# Altium schematic sheet Pulse.SchDoc(Pulse)
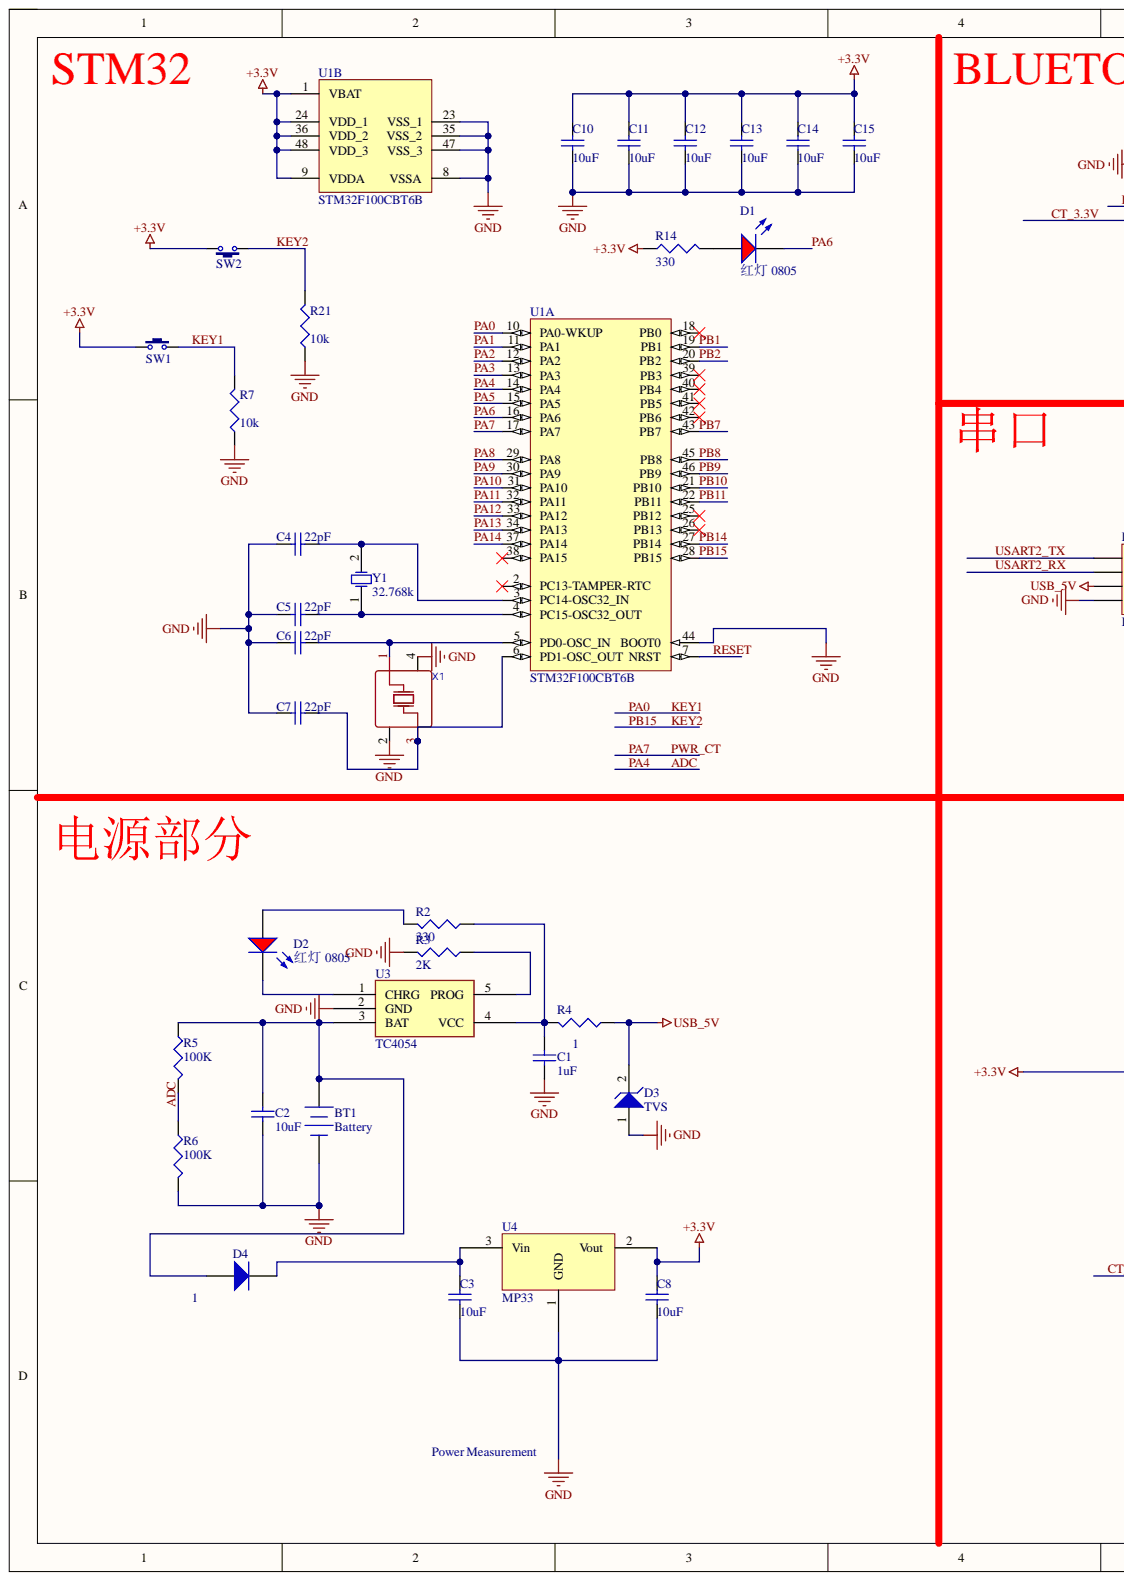
[diagram p0: Pulse.SchDoc, left]
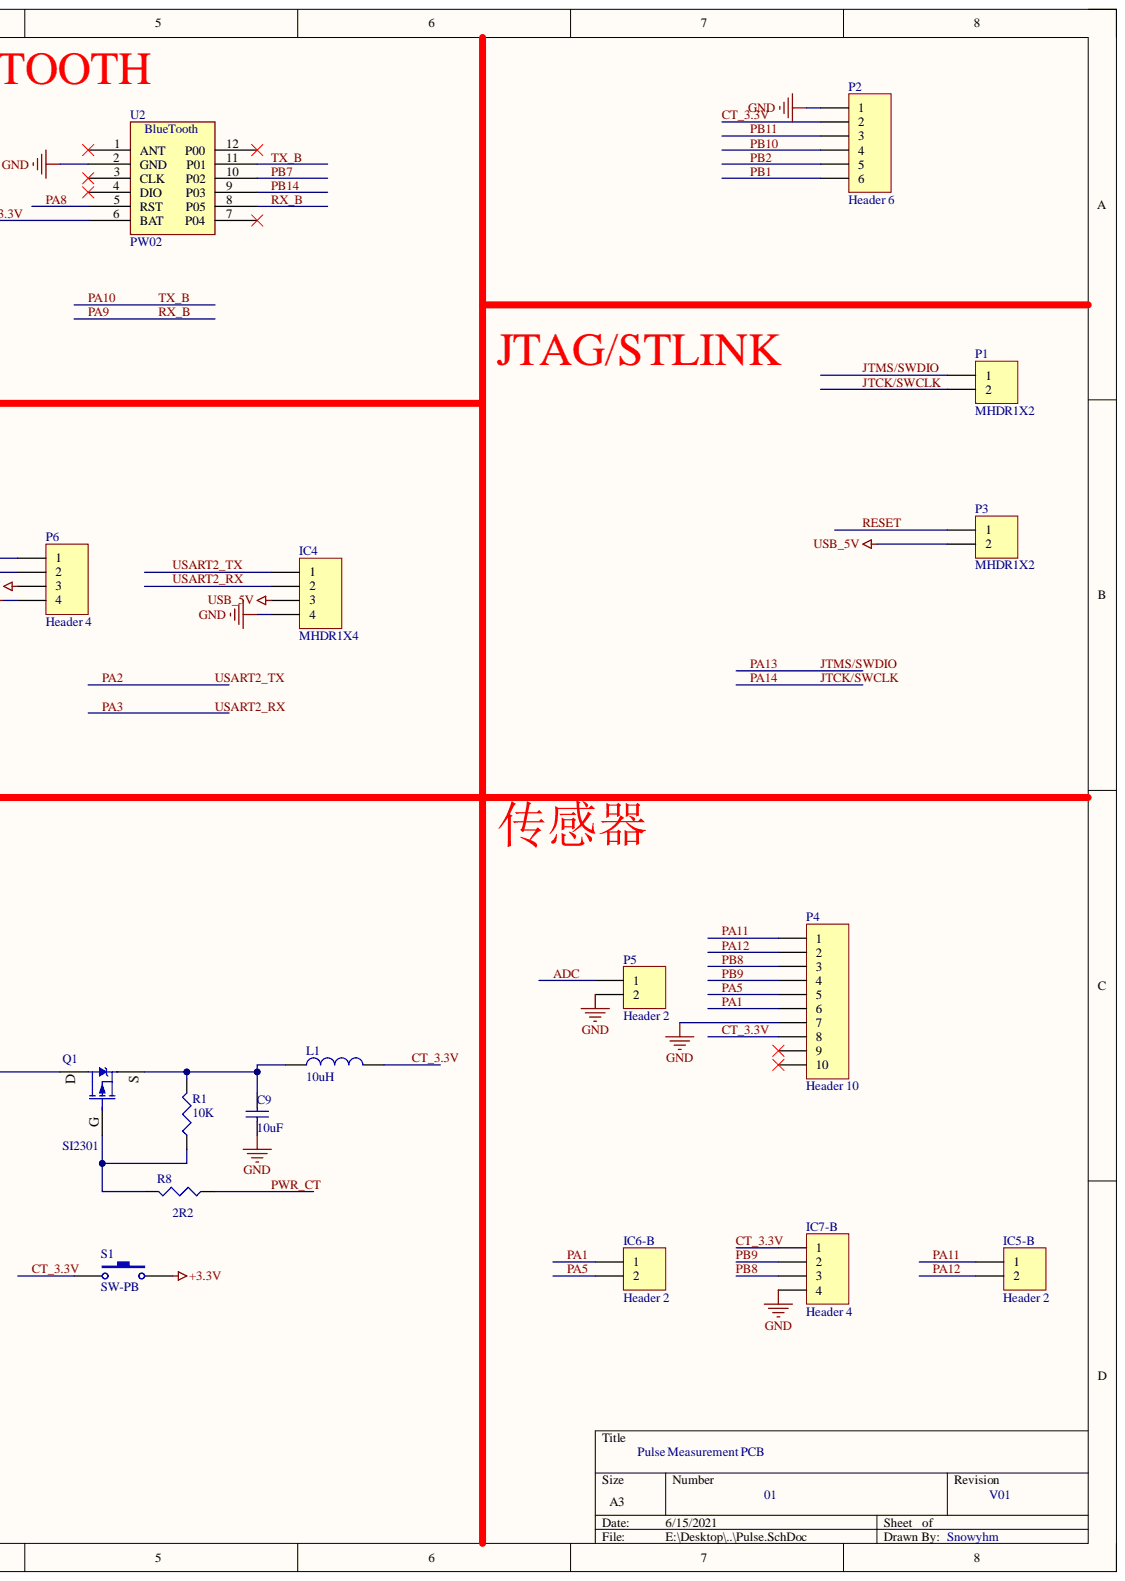
[diagram p1: Pulse.SchDoc, right]

## Parts
BT1  Battery  [BAT-2]
C1  value 1uF  [LC-0603_C]  (hidden comment: Cap Semi)
C2  value 10uF  [LC-0603_C]  (hidden comment: Cap Semi)
C3  value 10uF  [LC-0603_C]  (hidden comment: Cap Semi)
C4  value 22pF  [LC-0603_C]  (hidden comment: Cap Semi)
C5  value 22pF  [LC-0603_C]  (hidden comment: Cap Semi)
C6  value 22pF  [LC-0603_C]  (hidden comment: Cap Semi)
C7  value 22pF  [LC-0603_C]  (hidden comment: Cap Semi)
C8  value 10uF  [LC-0603_C]  (hidden comment: Cap Semi)
C9  value 10uF  [LC-0603_C]  (hidden comment: Cap Semi)
C10  value 10uF  [LC-0603_C]  (hidden comment: Cap Semi)
C11  value 10uF  [LC-0603_C]  (hidden comment: Cap Semi)
C12  value 10uF  [LC-0603_C]  (hidden comment: Cap Semi)
C13  value 10uF  [LC-0603_C]  (hidden comment: Cap Semi)
C14  value 10uF  [LC-0603_C]  (hidden comment: Cap Semi)
C15  value 10uF  [LC-0603_C]  (hidden comment: Cap Semi)
D1  红灯 0805  Package: 0805  [LC-0805_LED_S1]
D2  红灯 0805  [LC-0805_LED_S1]
D3  TVS  [LC-SOD-123]
D4  1  [LC-SOD-123]
IC4  MHDR1X4  [MHDR1X4]
IC5-B  Header 2  [HDR1X2]
IC6-B  Header 2  [HDR1X2]
IC7-B  Header 4  [HDR1X4]
L1  10uH  [L 0805]
P1  MHDR1X2  [MHDR1X2]
P2  Header 6  [HDR1X6]
P3  MHDR1X2  [MHDR1X2]
P4  Header 10  [8P]
P5  Header 2  [HDR1X2]
P6  Header 4  [fpc_4p]
Q1  SI2301  [SOT23-3N]
R1  value 10K  [LC-0603_R]  (hidden comment: Res3)
R2  value 330  [LC-0603_R]  (hidden comment: Res3)
R3  value 2K  [LC-0603_R]  (hidden comment: Res3)
R4  value 1  [LC-0603_R]  (hidden comment: Res3)
R5  value 100K  [LC-0603_R]  (hidden comment: Res3)
R6  value 100K  [LC-0603_R]  (hidden comment: Res3)
R7  value 10k  [LC-0603_R]  (hidden comment: Res3)
R8  value 2R2  [LC-0603_R]  (hidden comment: Res3)
R14  value 330  [LC-0603_R]  (hidden comment: Res3)
R21  value 10k  [LC-0603_R]  (hidden comment: Res3)
S1  SW-PB  [SW-SMD_DSHP01TSGET]
SW1  3x6x5  [button]  (value not drawn)
SW2  3x6x5  [button]  (value not drawn)
U1  STM32F100CBT6B  [STM-LQFP48_L]  (parts A,B)
U2  PW02  [bluetooth]
U3  TC4054  [SOT23-5]
U4  MP33  [LC-SOT-23(SOT-23-3)]
X1  (no value)  [OSC 3225-4P]
Y1  32.768k  [OSC 3215-2P]

## Nets
+3.3V: C8.1 C10.1 C11.1 C12.1 C13.1 C14.1 C15.1 Q1.3 R14.1 S1.2 SW1.1 SW2.2 U1.1(VBAT) U1.9(VDDA) U1.24(VDD_1) U1.36(VDD_2) U1.48(VDD_3) U4.2
GND: BT1.2 C1.1 C2.1 C3.2 C4.1 C5.1 C6.1 C7.1 C8.2 C9.2 C10.2 C11.2 C12.2 C13.2 C14.2 C15.2 D3.1 IC4.4 IC7-B.4 P2.1 P4.7 P5.2 P6.4 R3.2 R6.2 R7.1 R21.1 U1.8(VSSA) U1.23(VSS_1) U1.35(VSS_2) U1.44(BOOT0) U1.47(VSS_3) U2.2(GND) U3.2(GND) U4.1 X1.2 X1.4
USB_5V: D3.2 IC4.3 P3.2 P6.3 R4.1
ADC: P5.1 R5.2 R6.1 U1.14(PA4)
CT_3.3V: IC7-B.1 L1.2 P2.2 P4.8 S1.1 U2.6(BAT)
JTCK/SWCLK: P1.2 U1.37(PA14)
JTMS/SWDIO: P1.1 U1.34(PA13)
KEY1: R7.2 SW1.2 U1.10(PA0-WKUP)
KEY2: R21.2 SW2.1 U1.28(PB15)
PA1: IC6-B.1 P4.6 U1.11(PA1)
PA2: IC4.1 P6.1 U1.12(PA2)
PA3: IC4.2 P6.2 U1.13(PA3)
PA5: IC6-B.2 P4.5 U1.15(PA5)
PA6: D1.K U1.16(PA6)
PA7: R8.1 U1.17(PA7)
PA8: U1.29(PA8) U2.5(RST)
PA9: U1.30(PA9) U2.8(P05)
PA10: U1.31(PA10) U2.11(P01)
PA11: IC5-B.1 P4.1 U1.32(PA11)
PA12: IC5-B.2 P4.2 U1.33(PA12)
PB1: P2.6 U1.19(PB1)
PB2: P2.5 U1.20(PB2)
PB7: U1.43(PB7) U2.10(P02)
PB8: IC7-B.3 P4.3 U1.45(PB8)
PB9: IC7-B.2 P4.4 U1.46(PB9)
PB10: P2.4 U1.21(PB10)
PB11: P2.3 U1.22(PB11)
PB14: U1.27(PB14) U2.9(P03)
RESET: P3.1 U1.7(NRST)
NetBT1_1: BT1.1 C2.2 D4.1 R5.1 U3.3(BAT)
NetC1_2: C1.2 R2.1 R4.2 U3.4(VCC)
NetC3_1: C3.1 D4.2 U4.3
NetC4_2: C4.2 U1.3(PC14-OSC32_IN) Y1.2
NetC5_2: C5.2 U1.4(PC15-OSC32_OUT) Y1.1
NetC6_2: C6.2 U1.5(PD0-OSC_IN) X1.1
NetC7_2: C7.2 U1.6(PD1-OSC_OUT) X1.3
NetC9_1: C9.1 L1.1 Q1.2 R1.2
NetD1_A: D1.A R14.2
NetD2_A: D2.A R2.2
NetD2_K: D2.K U3.1(CHRG)
NetQ1_1: Q1.1 R1.1 R8.2
NetR3_1: R3.1 U3.5(PROG)

Not connected: P4 9 10; U1 2(PC13-TAMPER-RTC) 18(PB0) 25(PB12) 26(PB13) 38(PA15) 39(PB3) 40(PB4) 41(PB5) 42(PB6); U2 1(ANT) 3(CLK) 4(DIO) 7(P04) 12(P00)

## Library data of the parts
BT1 = Battery: Multicell Battery; Component Kind: Standard; LatestRevisionDate: 17-Jul-2002; LatestRevisionNote: Re-released for DXP Platform.; Library Name: Miscellaneous Devices.IntLib; PackageDescription: Battery; 2 Leads; PackageReference: BAT-2; Published: 24-Mar-1999; Publisher: Altium Limited
C1-C15 = Cap Semi: Capacitor (Semiconductor SIM Model); Component Kind: Standard; LatestRevisionDate: 29-May-2009; LatestRevisionNote: IPC-7351 Footprint Added.; Library Name: Miscellaneous Devices.IntLib; PackageDescription: Chip Capacitor; PackageReference: C1206; Published: 8-Jun-2000; Signal Integrity: Cap Semi; Simulation: CAP; Publisher: Altium Limited
D1 D2 = 0805 红灯: 发光二极管; Component Kind: Standard; ComponentLink1Description: 供应商链接; ComponentLink1URL: http://www.szlcsc.com/product/details_2668.html; Library Name: Miscellaneous Devices LC.IntLib; Supplier: LC; SuppliersPartNumber: C2295
  D2: Package: 0805
D3 = D Zener: Zener Diode; Component Kind: Standard; LatestRevisionDate: 17-Jul-2002; LatestRevisionNote: Re-released for DXP Platform.; Library Name: Miscellaneous Devices.IntLib; PackageDescription: Diode, Thru-Hole; 2 Leads; 0.7 in Pin Spacing; PackageReference: DIODE-0.7; Published: 8-Jun-2000; Signal Integrity: D Zener; Simulation: ZENER; Publisher: Altium Limited
D4 = SOD-323 1N4148WS T4: 二极管; Component Kind: Standard; ComponentLink1Description: 供应商链接; ComponentLink1URL: http://www.szlcsc.com/product/details_2485.html; Library Name: Miscellaneous Devices LC.IntLib; Package: SOD-323; Supplier: LC; SuppliersPartNumber: C2128
IC4 = MHDR1X4: Header, 4-Pin; Component Kind: Standard; LatestRevisionDate: 17-Jul-2002; LatestRevisionNote: Re-released for DXP Platform.; Library Name: Miscellaneous Connectors.IntLib; Signal Integrity: Connector; Publisher: Altium Limited
IC5-B IC6-B P5 = Header 2: Header, 2-Pin; Component Kind: Standard; LatestRevisionDate: 17-Jul-2002; LatestRevisionNote: Re-released for DXP Platform.; Library Name: Miscellaneous Connectors.IntLib; Signal Integrity: Connector; Publisher: Altium Limited
IC7-B P6 = Header 4: Header, 4-Pin; Component Kind: Standard; LatestRevisionDate: 17-Jul-2002; LatestRevisionNote: Re-released for DXP Platform.; Library Name: Miscellaneous Connectors.IntLib; Signal Integrity: Connector; Publisher: Altium Limited
L1 = L: 小功率贴片电感; 淘宝ID: 封装库来自淘宝 ID：黄晶宝石; BOM名称: 小功率贴片电感; Component Kind: Standard; Library Name: 0.1 - 电阻-电容-电感.SchLib; 联系售后: https://shop463601444.taobao.com
P1 P3 = MHDR1X2: Header, 2-Pin; Component Kind: Standard; LatestRevisionDate: 17-Jul-2002; LatestRevisionNote: Re-released for DXP Platform.; Library Name: Miscellaneous Connectors.IntLib; Signal Integrity: Connector; Publisher: Altium Limited
P2 = Header 6: Header, 6-Pin; Component Kind: Standard; LatestRevisionDate: 17-Jul-2002; LatestRevisionNote: Re-released for DXP Platform.; Library Name: Miscellaneous Connectors.IntLib; Signal Integrity: Connector; Publisher: Altium Limited
P4 = Header 10: Header, 10-Pin; Component Kind: Standard; LatestRevisionDate: 17-Jul-2002; LatestRevisionNote: Re-released for DXP Platform.; Library Name: Miscellaneous Connectors.IntLib; Signal Integrity: Connector; Publisher: Altium Limited
Q1 = SI2301: P沟道场效应管; BOM名称: P沟道场效应管; Component Kind: Standard; Library Name: 0.5 - 场效应管-可控硅.SchLib; 学习资源: https://shop463601444.taobao.com
R1-R8 R14 R21 = Res3: Resistor; Component Kind: Standard; LatestRevisionDate: 29-May-2009; LatestRevisionNote: IPC-7351 Footprint Added.; Library Name: Miscellaneous Devices.IntLib; PackageDescription: Chip Resistor; PackageReference: J1-0603; Published: 8-Jun-2000; Signal Integrity: Res; Simulation: RESISTOR; Publisher: Altium Limited
S1 = SW-PB: Switch; Component Kind: Standard; LatestRevisionDate: 17-Jul-2002; LatestRevisionNote: Re-released for DXP Platform.; Library Name: Miscellaneous Devices.IntLib; PackageDescription: Switch; 2 Leads; PackageReference: SPST-2; Published: 24-Mar-1999; Publisher: Altium Limited
SW1 SW2 = TSW 3x6: 3x6轻触开关; 免费技术支持，联系售后: 唯一出售店铺网址https://shop463601444.taobao.com; 封装库来自淘宝卖家 ID：黄晶宝石: 其他店铺购入均为盗版假冒封装库，请立即申请退款，本店3~10元现金奖励; BOM名称: 3x6轻触开关; Component Kind: Standard; Library Name: 0.7 - 常用按键-开关-蜂鸣器-电池.SchLib
U1 = STM32F100CBT6B: ARM Cortex-M3 32-bit MCU, 128 KB Flash, 8 KB Internal RAM, 37 I/Os, 48-pin LQFP, -40 to 85 degC, Tray; A/D Converter: 1x12-bit (10 Channel); D/A Converter: 2x12-bit (2 Channel); FLASH Size (Prog) (kB): 128; I/Os (High Current): 37; Internal RAM Size (kB): 8; Operating Frequency(F) (Processor speed) (MHz): 24; Supply Voltage(Vcc) min (V): 2; Component Kind: Standard; ComponentLink1Description: Manufacturer URL; ComponentLink1URL: http://www.st.com/web/en/home.html; ComponentLink2Description: Datasheet; ComponentLink2URL: http://www.st.com/st-web-ui/static/active/en/resource/technical/document/datasheet/CD00251732.pdf; ComponentLink3Description: Package Specification; ComponentLink3URL: http://www.st.com/st-web-ui/static/active/en/resource/technical/document/datasheet/DM00058181.pdf; Core: ARM Cortex-M3; DatasheetVersion: Rev. 7; Library Name: STMicroelectronics STM32 F1.IntLib; Mounting Technology: Surface Mount; PackageDescription: 48-Pin Low Profile Quad Flat Package, 7 x 7 mm Body, 0.5 mm Pitch; PackageReference: LQFP48; PackageVersion: Rev. 6, 06/2013; Packing: Tray; PartNumber: STM32F100CBT6B; Supplier 1: Digi-Key; Supplier 2: Farnell; Supplier 3: Newark; Supplier 4: Newark; Supplier 5: Mouser; Supplier Part Number 1: 497-10498-ND; Supplier Part Number 2: 1838512; Supplier Part Number 3: 86R1308; Supplier Part Number 4: 76R0985; Supplier Part Number 5: 511-STM32F100CBT6B
U2 = PW02: BlueTooth; Component Kind: Standard; Library Name: PulsePCB.SchLib
U4 = AMS1117: 三端稳压芯片; Component Kind: Standard; Library Name: 电源芯片.SchLib
X1 = SMD-3225_4P12M12PF10PPM: Component Kind: Standard
Y1 = XTAL: Crystal Oscillator; Component Kind: Standard; LatestRevisionDate: 17-Jul-2002; LatestRevisionNote: Re-released for DXP Platform.; Library Name: Miscellaneous Devices.IntLib; PackageDescription: Crystal, Thru-Hole; 2 Leads; Body 3.1 x 8.2 mm (Dia.xH); PackageReference: R38; Published: 8-Jun-2000; Simulation: XTAL; Publisher: Altium Limited
U3 = TC4054: Component Kind: Standard; Library Name: PulsePCB.SchLib
